# Altium schematic sheet Barometer_11_Click_v001.SchDoc(Barometer_11_Click_v001)
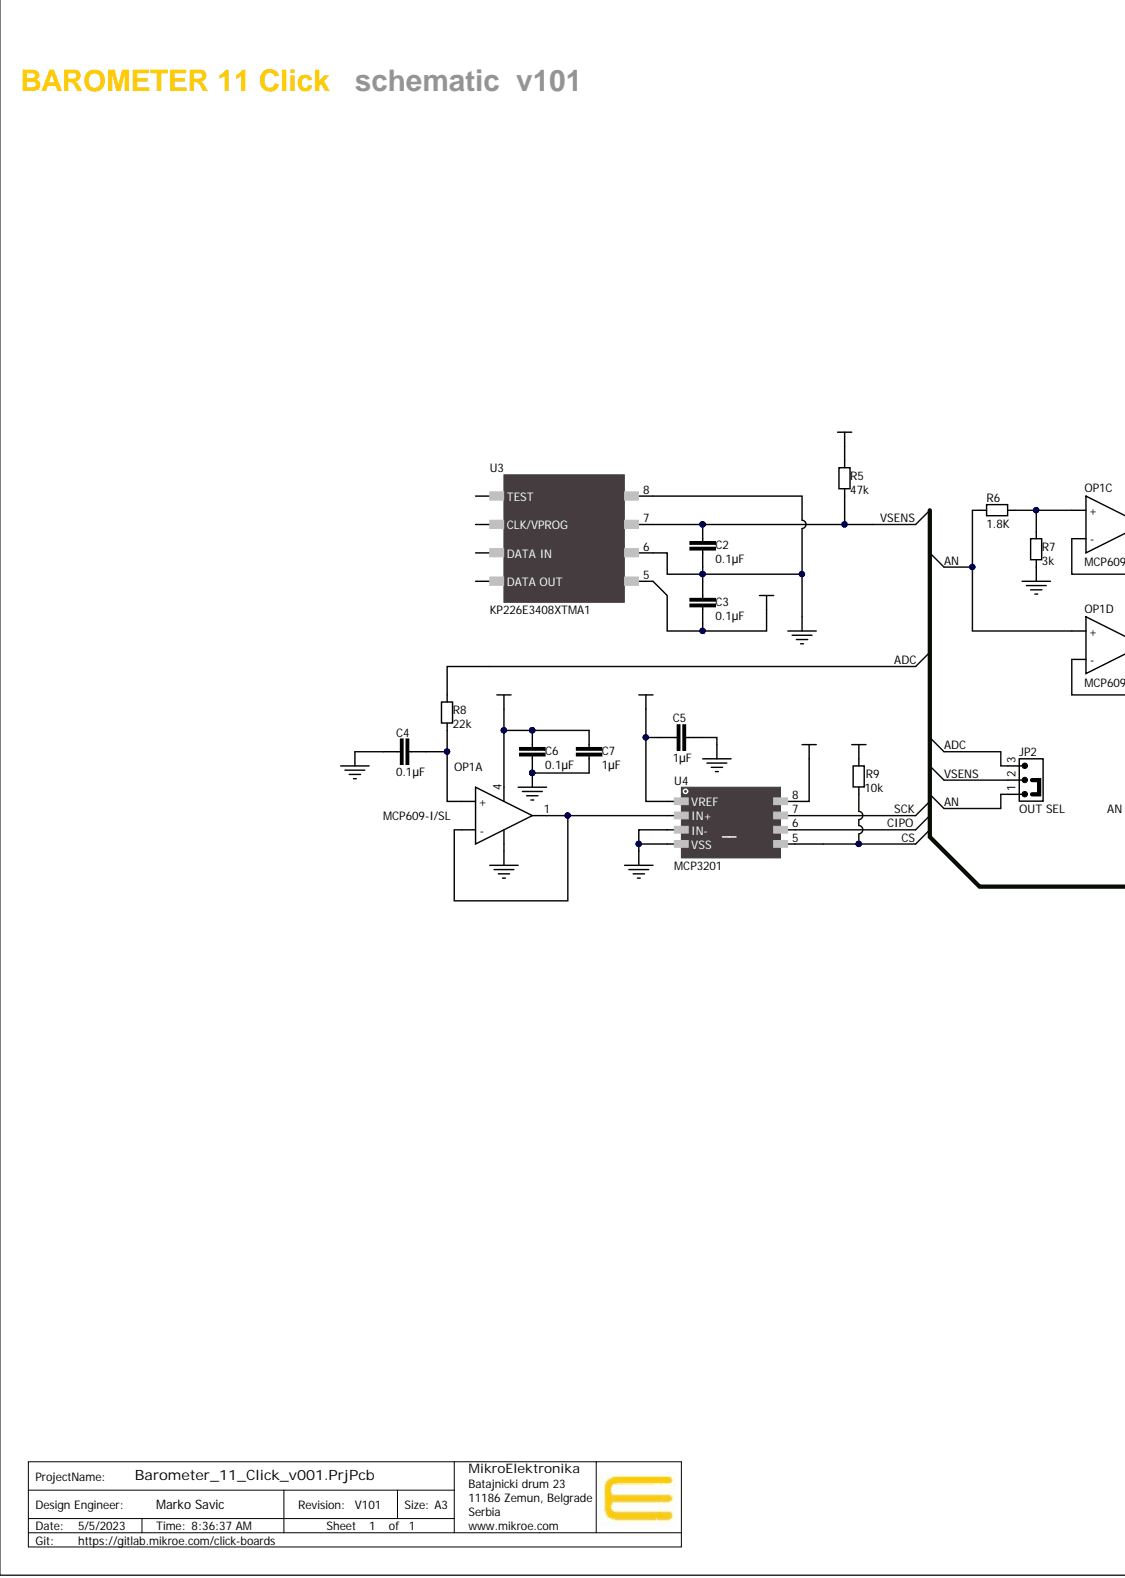
[diagram p0: Barometer_11_Click_v001.SchDoc, left]
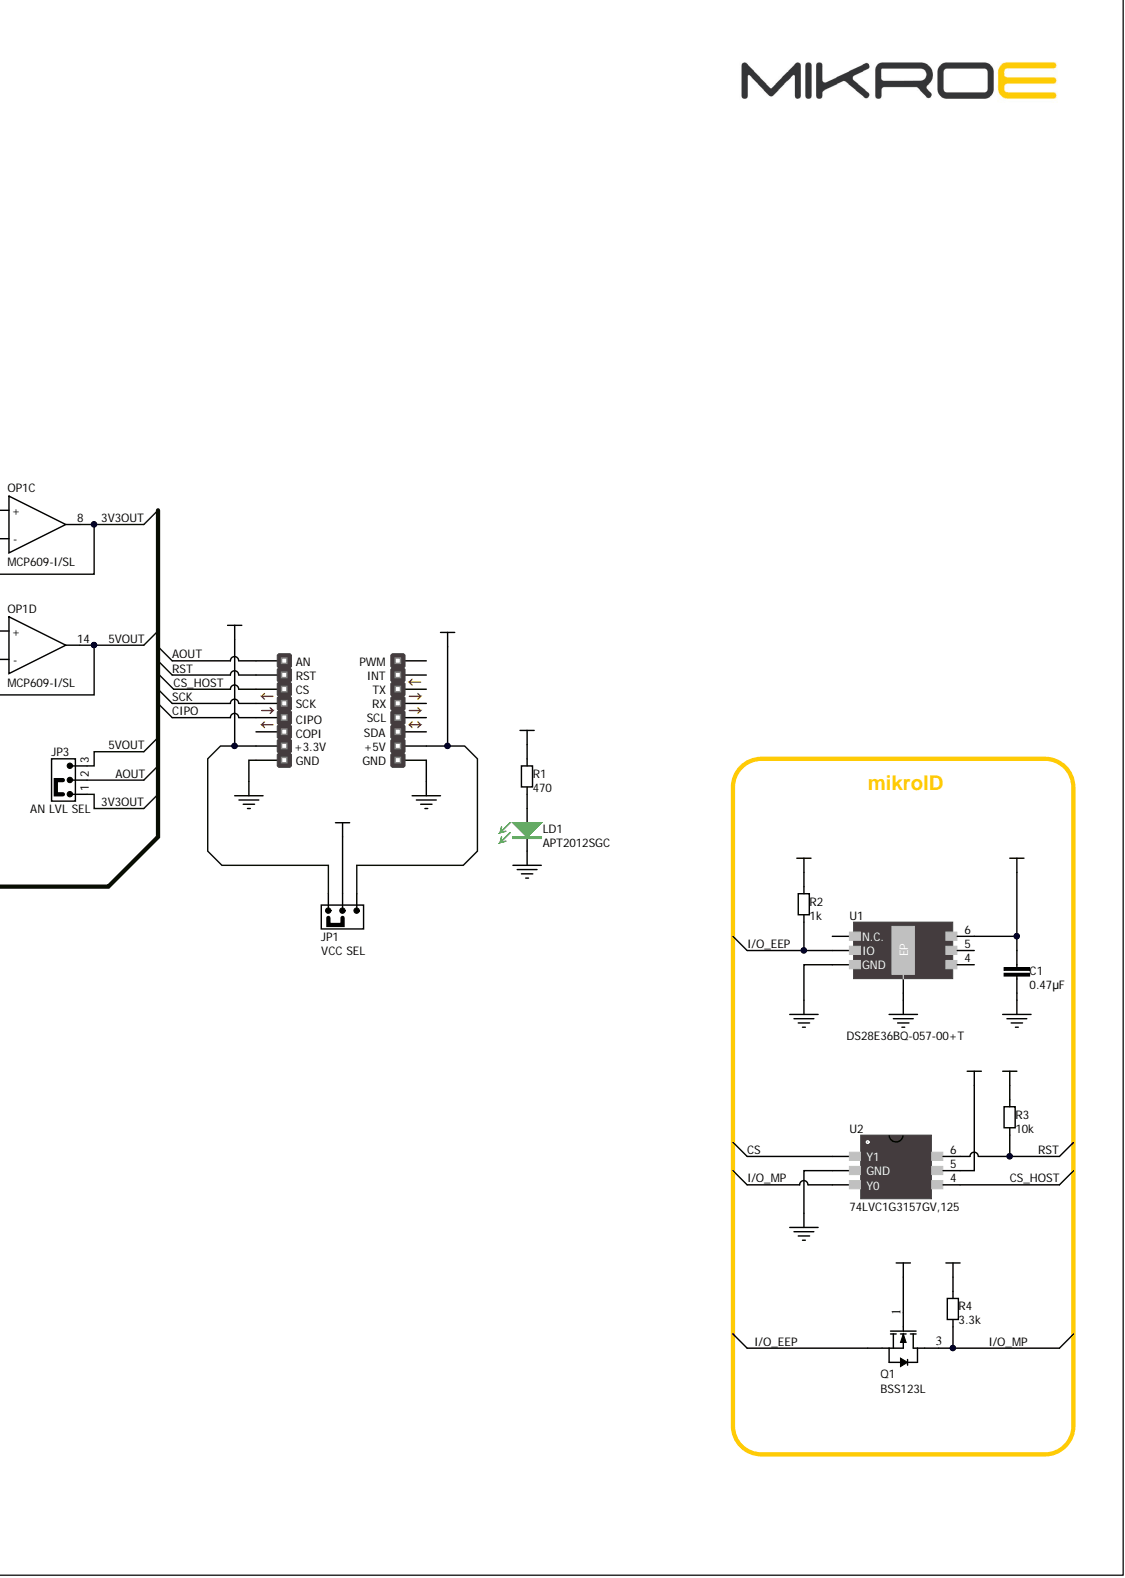
[diagram p1: Barometer_11_Click_v001.SchDoc, right]

## Parts
C1  0.47µF  [CAPC1005X55N-0402-NoOverlay]
C2  0.1µF  [CAPC1005X55N-0402-NoOverlay]
C3  0.1µF  [CAPC1005X55N-0402-NoOverlay]
C4  0.1µF  [CAPC1005X55N-0402-NoOverlay]
C5  1µF  [CAPC1005X55N-0402-NoOverlay]
C6  0.1µF  [CAPC1005X55N-0402-NoOverlay]
C7  1µF  [CAPC1005X55N-0402-NoOverlay]
JP1  VCC SEL  [JUMPER-0805-V2-12]
JP2  OUT SEL  [JUMPER-0805-V2-12]
JP3  AN LVL SEL  [JUMPER-0805-V2-12]
LD1  APT2012SGC  [DIOGREENC2013X120N-0805-NoOverlay]
MBD1  MIKROBUS DEVICE CONN  [New Click Template_MIDI-ID]  (value not drawn)  (no BOM)
OP1  MCP609-I/SL  [SOIC127P600X175-14L87N-SOIC-14-NoOverlay]  (parts A,C,D)
Q1  BSS123L  [SOT95P240X110-3N-NoOverlay]
R1  470  [RESC2013X38N-0805-NOOVERLAY]
R2  1k  [RESC1005X40N-0402-NOOVERLAY]
R3  10k  [RESC1005X40N-0402-NOOVERLAY]
R4  3.3k  [RESC1005X40N-0402-NOOVERLAY]
R5  47k  [RESC2013X38N-0805-NOOVERLAY]
R6  1.8K  [RESC2013X38N-0805-NOOVERLAY]
R7  3k  [RESC2013X38N-0805-NOOVERLAY]
R8  22k  [RESC2013X38N-0805-NOOVERLAY]
R9  10k  [RESC2013X38N-0805-NOOVERLAY]
U1  DS28E36BQ-057-00+T  [SON95P300X300X100-7T160X250N-NoOverlay]
U2  74LVC1G3157GV,125  [SOT95P275X145-6L20N-SOT23-6-NoOverlay]
U3  KP226E3408XTMA1  [PG-DSOF-8-16 - NoOverlay]
U4  MCP3201  [SOIC127P600X175-8N-NoOverlay]

## Nets
3V3: C1.2 JP1.1 MBD1.7(+3.3V) Q1.1 R2.2 U1.6
5V: C3.1 C5.1 C6.2 C7.2 JP1.3 MBD1.10(+5V) OP1.4 R5.2 U3.5 U4.1(VREF)
GND: C1.1 C2.1 C3.2 C4.1 C5.2 C6.1 C7.1 LD1.1 MBD1.8(GND) MBD1.9(GND) OP1.11 R7.1 U1.3(GND) U1.7(EP) U2.2(GND) U3.6 U3.8 U4.3(IN-) U4.4(VSS)
VCC: JP1.2 R1.2 R3.2 R4.2 R9.2 U2.5 U4.8
3V3OUT: JP3.1 OP1.8 OP1.9(-)
5VOUT: JP3.3 OP1.13(-) OP1.14
ADC: JP2.3 R8.2
AN: JP2.1 OP1.12(+) R6.2
AOUT: JP3.2 MBD1.1(AN)
CIPO: MBD1.5(CIPO) U4.6
CS: R9.1 U2.1(Y1) U4.5
CS_HOST: MBD1.3(CS) U2.4
I/O_EEP: Q1.2 R2.1 U1.2(IO)
I/O_MP: Q1.3 R4.1 U2.3(Y0)
RST: MBD1.2(RST) R3.1 U2.6
SCK: MBD1.4(SCK) U4.7
VSENS: C2.2 JP2.2 R5.1 U3.7
NetC4_2: C4.2 OP1.3(+) R8.1
NetLD1_2: LD1.2 R1.1
NetOP1_1: OP1.1 OP1.2(-) U4.2(IN+)
NetOP1_10: OP1.10(+) R6.1 R7.2
N00032:

Not connected: MBD1 6(COPI) 11(SDA) 12(SCL) 13(RX) 14(TX) 15(INT) 16(PWM); U1 1(N.C.) 4 5; U3 1(TEST) 2(CLK/VPROG) 3(DATA_IN) 4(DATA_OUT)

## Library data of the parts
C1 = 2911010036980: CAP CER 0.47UF 50V X5R 0402; Manufacturer Part Number: UMK105ABJ474KV-F; Manufacturer: Taiyo Yuden; Tolerance: ±10%; item_id: 4359; id: 38; Assembly: SMD; Voltage Rated: 50V; Temperature Coefficient: X5R; Part Type: Capacitors; Package Case: 0402 (1005 Metric); Library Name: capacitors.IntLib; Family: CERAMIC CAPACITOR; Datasheet URL: http://ds.yuden.co.jp/TYCOMPAS/ut/detail.do?productNo=UMK105ABJ474KV-F&fileName=UMK105ABJ474KV-F_SS&mode=specSheetDownload; Component Kind: Standard; Category: Capacitors; Capacitance: 0.47µF; Barcode: 2911010036980
C2-C4 C6 = 2911010025755: CAP CER 0.1UF 10V X5R 0402; Manufacturer Part Number: LMK105BJ104KV-F; Manufacturer: Taiyo Yuden; Tolerance: ±10%; item_id: 3484; id: 63; Assembly: SMD; Voltage Rated: 10V; Temperature Coefficient: X5R; Part Type: Capacitors; Package Case: 0402 (1005 Metric); Library Name: capacitors.IntLib; Family: CERAMIC CAPACITOR; Datasheet URL: http://ds.yuden.co.jp/TYCOMPAS/ut/detail.do?productNo=LMK105BJ104KV-F&fileName=LMK105BJ104KV-F_SS&mode=specSheetDownload; Component Kind: Standard; Category: Capacitors; Capacitance: 0.1µF; Barcode: 2911010025755
C5 C7 = 3500000205247: CAP CER 1UF 10V X5R 0402; Manufacturer Part Number: CL05A105KP5NNND; Manufacturer: Samsung Electro-Mechanics; Tolerance: ±10%; item_id: 18660; id: 781; Assembly: SMD; Voltage Rated: 10V; Temperature Coefficient: X5R; Part Type: Capacitors; Package Case: 0402 (1005 Metric); Library Name: capacitors.IntLib; Family: CERAMIC CAPACITOR; Datasheet URL: http://www.samsungsem.com/kr/support/product-search/mlcc/CL05A105KP5NNND.jsp; Component Kind: Standard; Category: Capacitors; Capacitance: 1µF; Barcode: 3500000205247
JP1-JP3 = SEL JMP 0805 SMD: Manufacturer Part Number: RC0805JR-070RL; Manufacturer: Yageo; Tolerance: Jumper; Resistance: 0.0; Power: 0.125W, 1/8W; Barcode: 0100000003947; Assembly: SMD; Temperature Coefficient: -; Part Type: Resistors; Package Case: 0805 (2012 Metric); Library Name: ME-Integrated_Library.IntLib; Datasheet URL: http://www.yageo.com/NewPortal/yageodocoutput?fileName=/pdf/R-Chip/PYu-RC_Group_51_RoHS_L_3.pdf; Component Kind: Standard; Category: Resistors
LD1 = 2911010024338: LED GREEN CLEAR 0805 SMD; Manufacturer: Kingbright; Wavelength: 568nm; Voltage Forward: 2.2V; Lens Color: Colorless; item_id: 3342; id: 28; Part Type: Optoelectronics; Package Case: 0805 (2012 Metric); Manufacturer Part Number: APT2012SGC; Library Name: optoelectronics.IntLib; Lens Transparency: Clear; Family: LED; Datasheet URL: http://www.kingbrightusa.com/images/catalog/SPEC/APT2012SGC.pdf; Component Kind: Standard; Color: Green; Category: Optoelectronics; Barcode: 2911010024338; Assembly: SMD
MBD1 = MIKROBUS DEVICE CONN: mikroBUS Target Header; Library Name: ME-Integrated_Library.IntLib
OP1 = 0100000102886: IC OPAMP GP 155KHZ RRO 14SOIC; Manufacturer: Microchip Technology; Voltage Supply Single Dual: 2.5 V ~ 6 V; Output Type: Rail-to-Rail; item_id: 9402; id: 600; Current  Supply: 18.7µA; Current Output: 17mA; Assembly: SMD; Amplifier Type: General Purpose; Part Type: Integrated Circuits; Package Case: 14-SOIC (0.154", 3.90mm Width); Manufacturer Part Number: MCP609-I/SL; Library Name: integrated_circuits.IntLib; Gain Bandwidth Product: 155kHz; Family: Linear Amplifiers; Datasheet URL: http://www.microchip.com/mymicrochip/filehandler.aspx?ddocname=en011259; Component Kind: Standard; Category: Integrated Circuits (ICs); Barcode: 0100000102886
Q1 = 3500000213921: MOSFET N-CH 100V 0.17A SOT-23; Manufacturer: ON Semiconductor; Vgs: 2V @ 1mA; Rds On max: 6 Ohm @ 170mA, 10V; item_id: 19528; id: 481; FET Type: N-Channel; Current  Continuous Drain: 170mA (Ta); Assembly: SMD; Part Type: Discrete Semiconductors; Package Case: TO-236-3, SC-59, SOT-23-3; Manufacturer Part Number: BSS123L; Library Name: discrete_semiconductors.IntLib; Family: FET; Drain to Source Voltage: 100V; Datasheet URL: https://www.onsemi.com/pub/Collateral/BSS123LT1-D.PDF; Component Kind: Standard; Category: Discrete Semiconductor Products; Barcode: 3500000213921
R1 = 0100000007921: RES SMD 470 OHM 1% 1/8W 0805; Manufacturer Part Number: RC0805FR-07470RL; Manufacturer: Yageo; Temperature Coefficient: ±100ppm/°C; Power: 0.125W, 1/8W; item_id: 1082; id: 207; Tolerance: ±1%; Resistance: 470; Part Type: Resistors; Package Case: 0805 (2012 Metric); Library Name: resistors.IntLib; Family: RESISTOR; Datasheet URL: http://www.yageo.com/NewPortal/yageodocoutput?fileName=/pdf/R-Chip/PYu-RC_Group_51_RoHS_L_3.pdf; Component Kind: Standard; Category: Resistors; Barcode: 0100000007921; Assembly: SMD
R2 = 2911010036768: RES SMD 1K OHM 1% 1/16W 0402; Manufacturer Part Number: RC0402FR-071KL; Manufacturer: Yageo; Temperature Coefficient: ±100ppm/°C; Power: 0.063W, 1/16W; item_id: 4337; id: 72; Tolerance: ±1%; Resistance: 1k; Part Type: Resistors; Package Case: 0402 (1005 Metric); Library Name: resistors.IntLib; Family: RESISTOR; Datasheet URL: http://www.yageo.com/NewPortal/yageodocoutput?fileName=/pdf/R-Chip/PYu-RC_Group_51_RoHS_L_3.pdf; Component Kind: Standard; Category: Resistors; Barcode: 2911010036768; Assembly: SMD
R3 = 2911010036805: RES SMD 10K OHM 1% 1/16W 0402; Manufacturer Part Number: RC0402FR-0710KL; Manufacturer: Yageo; Temperature Coefficient: ±100ppm/°C; Recommended Rating: Platinum; Power: 0.063W, 1/16W; item_id: 4341; id: 68; Tolerance: ±1%; Resistance: 10k; Part Type: Resistors; Package Case: 0402 (1005 Metric); Library Name: resistors.IntLib; Family: RESISTOR; Datasheet URL: http://www.yageo.com/NewPortal/yageodocoutput?fileName=/pdf/R-Chip/PYu-RC_Group_51_RoHS_L_3.pdf; Component Kind: Standard; Category: Resistors; Barcode: 2911010036805; Assembly: SMD
R4 = 0100000084823: RES SMD 3.3K OHM 1% 1/16W 0402; Manufacturer Part Number: RC0402FR-073K3L; Manufacturer: Yageo; Temperature Coefficient: ±100ppm/°C; Power: 0.063W, 1/16W; item_id: 7597; id: 127; Tolerance: ±1%; Resistance: 3.3k; Part Type: Resistors; Package Case: 0402 (1005 Metric); Library Name: resistors.IntLib; Family: RESISTOR; Datasheet URL: http://www.yageo.com/NewPortal/yageodocoutput?fileName=/pdf/R-Chip/PYu-RC_Group_51_RoHS_L_3.pdf; Component Kind: Standard; Category: Resistors; Barcode: 0100000084823; Assembly: SMD
R5 = 0100000004258: RES SMD 47K OHM 1% 1/8W 0805; Manufacturer Part Number: RC0805FR-0747KL; Manufacturer: Yageo; Temperature Coefficient: ±100ppm/°C; Power: 0.125W, 1/8W; item_id: 697; id: 211; Tolerance: ±1%; Resistance: 47k; Part Type: Resistors; Package Case: 0805 (2012 Metric); Library Name: resistors.IntLib; Family: RESISTOR; Datasheet URL: http://www.yageo.com/NewPortal/yageodocoutput?fileName=/pdf/R-Chip/PYu-RC_Group_51_RoHS_L_3.pdf; Component Kind: Standard; Category: Resistors; Barcode: 0100000004258; Assembly: SMD
R6 = 0100000007938: Manufacturer Part Number: CR21-1801-FL; Manufacturer: ASJ; Tolerance: 1%; Temperature Coefficient: 100ppm; Recommended Rating: Silver; Power: 0.125; item_id: 1083; id: 208; Assembly: SMD; Resistance: 1.8K; Part Type: Resistors; Package Case: 0805; Library Name: resistors.IntLib; Family: RESISTOR; Component Kind: Standard; Category: Resistors; Barcode: 0100000007938
R7 = 0100000002032: RES SMD 3K OHM 1% 1/8W 0805; Manufacturer Part Number: RC0805FR-073KL; Manufacturer: Yageo; Temperature Coefficient: ±100ppm/°C; Recommended Rating: Gold; Power: 0.125W, 1/8W; item_id: 468; id: 223; Tolerance: ±1%; Resistance: 3k; Part Type: Resistors; Package Case: 0805 (2012 Metric); Library Name: resistors.IntLib; Family: RESISTOR; Datasheet URL: http://www.yageo.com/NewPortal/yageodocoutput?fileName=/pdf/R-Chip/PYu-RC_Group_51_RoHS_L_3.pdf; Component Kind: Standard; Category: Resistors; Barcode: 0100000002032; Assembly: SMD
R8 = 0100000011362: RES SMD 22K OHM 1% 1/8W 0805; Manufacturer Part Number: RC0805FR-0722KL; Manufacturer: Yageo; Temperature Coefficient: ±100ppm/°C; Recommended Rating: Gold; Power: 0.125W, 1/8W; item_id: 1544; id: 118; Tolerance: ±1%; Resistance: 22k; Part Type: Resistors; Package Case: 0805 (2012 Metric); Library Name: resistors.IntLib; Family: RESISTOR; Datasheet URL: http://www.yageo.com/NewPortal/yageodocoutput?fileName=/pdf/R-Chip/PYu-RC_Group_51_RoHS_L_3.pdf; Component Kind: Standard; Category: Resistors; Barcode: 0100000011362; Assembly: SMD
R9 = 0100000001974: RES SMD 10K OHM 1% 1/8W 0805; Manufacturer Part Number: RC0805FR-0710KL; Manufacturer: Yageo; Temperature Coefficient: ±100ppm/°C; Recommended Rating: Platinum; Power: 0.125W, 1/8W; item_id: 462; id: 228; Tolerance: ±1%; Resistance: 10k; Part Type: Resistors; Package Case: 0805 (2012 Metric); Library Name: resistors.IntLib; Family: RESISTOR; Datasheet URL: http://www.yageo.com/NewPortal/yageodocoutput?fileName=/pdf/R-Chip/PYu-RC_Group_51_RoHS_L_3.pdf; Component Kind: Standard; Category: Resistors; Barcode: 0100000001974; Assembly: SMD
U1 = 3500000334893: DEEPCOVER SECURE AUTHENTICATOR 1; Manufacturer: Analog Devices Inc./Maxim Integrated; item_id: 30650; id: 3849; Assembly: SMD; Part Type: Integrated Circuits; Package Case: 6-WDFN Exposed Pad; Manufacturer Part Number: DS28E36BQ-057-00+T; Library Name: integrated_circuits.IntLib; Family: Memory; Datasheet URL: https://eu.mouser.com/datasheet/2/256/DS28E36-1551302.pdf; Component Kind: Standard; Category: Specialized ICs; Barcode: 3500000334893
U2 = 0100000107270: IC MUX/DEMUX 2X1 6TSOP; Manufacturer: NXP Semiconductors; item_id: 9841; id: 645; Assembly: SMD; Part Type: Integrated Circuits; Package Case: SC-74, SOT-457; Manufacturer Part Number: 74LVC1G3157GV,125; Library Name: integrated_circuits.IntLib; Family: Analog Switches, Multiplexers, Demultiplexers; Datasheet URL: https://assets.nexperia.com/documents/data-sheet/74LVC1G3157.pdf; Component Kind: Standard; Category: Integrated Circuits (ICs); Barcode: 0100000107270
U3 = KP226E3408XTMA1: Manufacturer: Infineon; Supplier Part Number: 448-KP226E3408XTMA1DKR-ND; Supplier: Digi-Key; Manufacturer Part Number: KP226E3408XTMA1; Component Kind: Standard; Library Name: Barometer_11_Click_v001.SchLib
U4 = 2911010026745: IC ADC 12BIT SINGLE CHAN 8SOIC; Manufacturer Part Number: MCP3201-CI/SN; Manufacturer: Microchip Technology; Voltage  Supply Digital: 2.7 V ~ 5.5 V; Number of Bits: 12; item_id: 3583; Input Type: Pseudo-Differential; id: 187; Data Interface: SPI; Configuration: S/H-ADC; Assembly: SMD; Voltage  Supply Analog: 2.7 V ~ 5.5 V; Part Type: Integrated Circuits; Package Case: 8-SOIC (0.154", 3.90mm Width); Library Name: integrated_circuits.IntLib; Features: -; Family: Analog to Digital Converters (ADC); Datasheet URL: http://www.microchip.com/mymicrochip/filehandler.aspx?ddocname=en011279; Component Kind: Standard; Category: Integrated Circuits (ICs); Barcode: 2911010026745
